# Altium schematic sheet PFE-EVSE.SchDoc
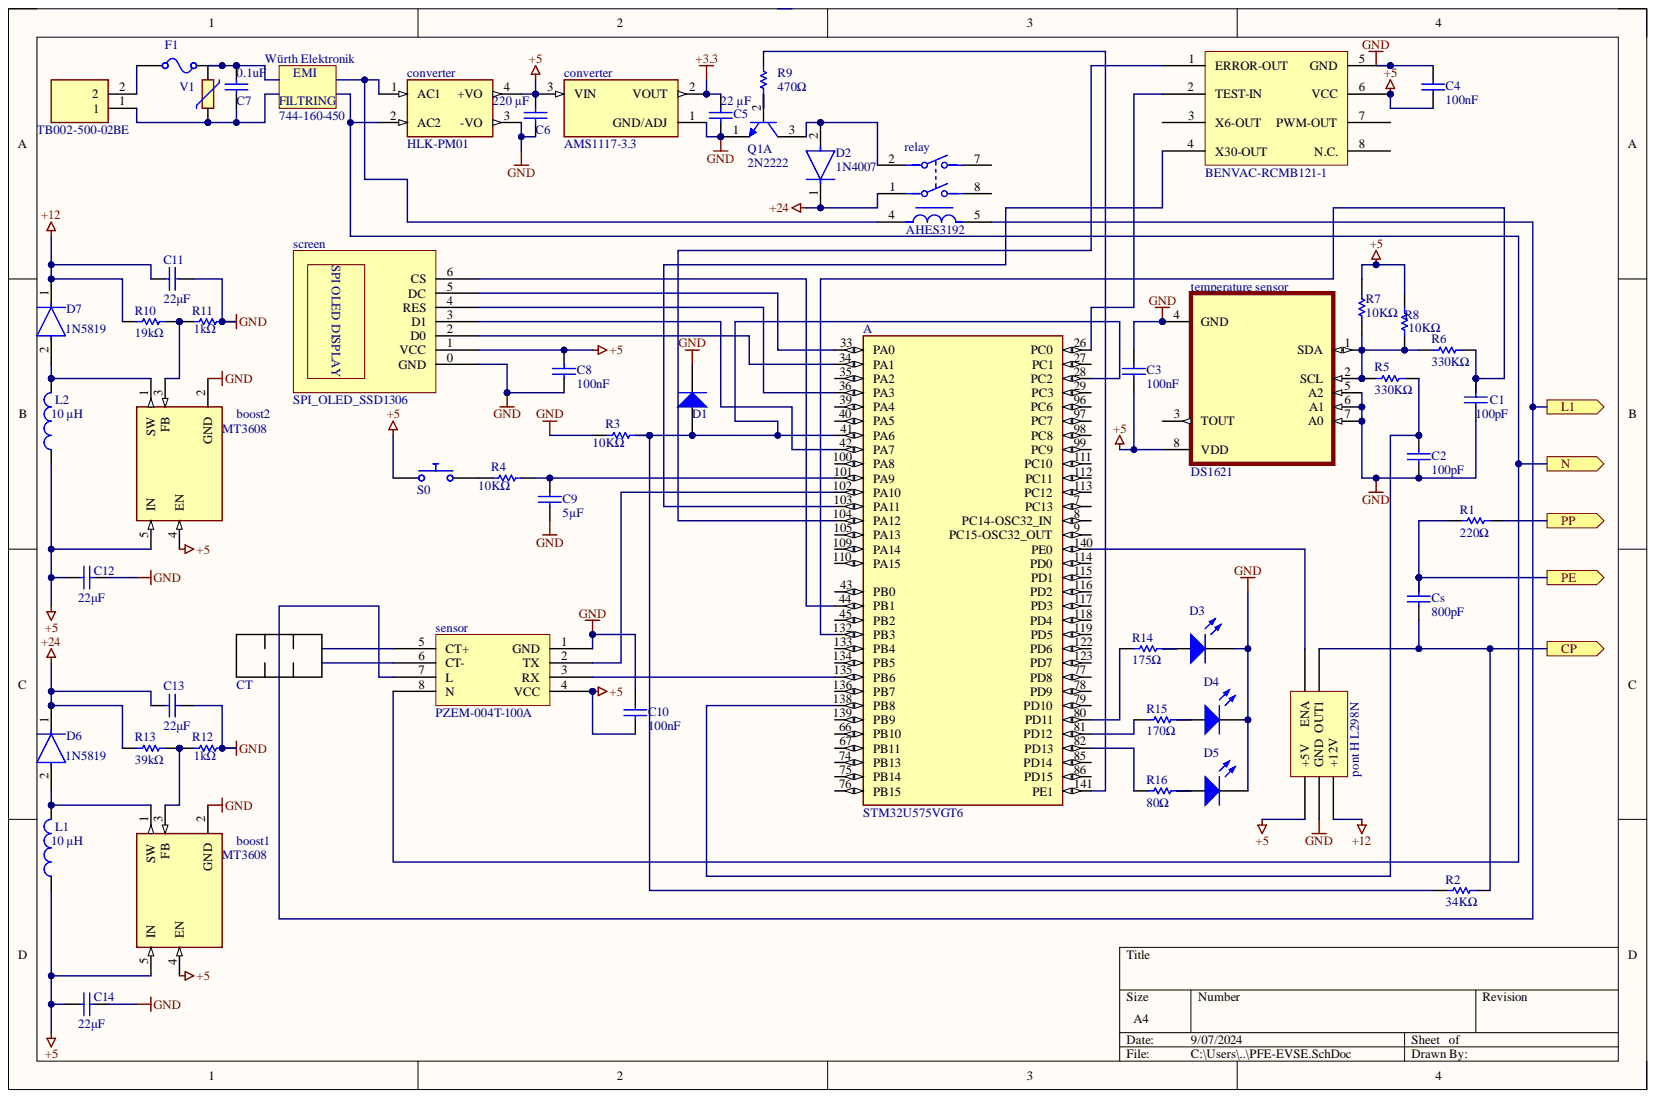
[diagram p0: PFE-EVSE.SchDoc]

## Parts
A  pont H L298N  (part )
A  Fuse  (value not drawn)
A  BENVAC-RCMB121-1
A  SPI_OLED_SSD1306
A  TB002-500-02BE  [CUI_TB002-500-02BE]
boost1  MT3608  [SOT95P280X145-6N]
boost2  MT3608  [SOT95P280X145-6N]
C1  value 100pF  (hidden comment: Capacitor)
C2  value 100pF  (hidden comment: Capacitor)
C3  value 100nF  (hidden comment: Capacitor)
C4  value 100nF  (hidden comment: Capacitor)
C5  Capacitor  (value not drawn)
C6  220 µF
C7  value 0.1uF  (hidden comment: Capacitor)
C8  value 100nF  (hidden comment: Capacitor)
C9  value 5µF  (hidden comment: Capacitor)
C10  value 100nF  (hidden comment: Capacitor)
C11  value 22μF  (hidden comment: Capacitor)
C12  value 22μF  (hidden comment: Capacitor)
C13  value 22μF  (hidden comment: Capacitor)
C14  value 22μF  (hidden comment: Capacitor)
converter  AMS1117-3.3  [SOT229P700X180-4N]
converter  HLK-PM01  [CONV_HLK-PM01]
Cs  value 800pF  (hidden comment: Capacitor)
D1  Diode  (value not drawn)
D2  1N4007  [FP-1N4007-MFG]
D3  Light-Emitting Diode  (value not drawn)
D4  Light-Emitting Diode  (value not drawn)
D5  Light-Emitting Diode  (value not drawn)
D6  (no value)  [FP-1N4007-MFG]
D7  (no value)  [FP-1N4007-MFG]
L1  value 10 μH  (hidden comment: Inductor)
L2  value 10 μH  (hidden comment: Inductor)
Q1  2N2222  [MLTC-TO-18-3]  (part A)
R1  value 220Ω  (hidden comment: Resistor)
R2  value 34KΩ  (hidden comment: Resistor)
R3  value 10KΩ  (hidden comment: Resistor)
R4  value 10KΩ  (hidden comment: Resistor)
R5  value 330KΩ  (hidden comment: Resistor)
R6  value 330KΩ  (hidden comment: Resistor)
R7  value 10KΩ  (hidden comment: Resistor)
R8  value 10KΩ  (hidden comment: Resistor)
R9  value 470Ω  (hidden comment: Resistor)
R10  value 19kΩ  (hidden comment: Resistor)
R11  value 1kΩ  (hidden comment: Resistor)
R12  value 1kΩ  (hidden comment: Resistor)
R13  value 39kΩ  (hidden comment: Resistor)
R14  value 175Ω  (hidden comment: Resistor)
R15  value 170Ω  (hidden comment: Resistor)
R16  value 80Ω  (hidden comment: Resistor)
relay  AHES3192  [FP-AHES3192-MFG]
S0  Push Button Normally Opened  (value not drawn)
sensor  PZEM-004T-100A
temperature_sensor  DS1621  [DIP794W47P254L991H457Q8]
V1  (no value)  [MOV-10D561]

## Ports
CP, L1, N, PE, PP

## Nets
+3.3: C5.2 converter.2
+5: A.1 A.6 A. boost1.4(EN) boost1.5(IN) boost2.4(EN) boost2.5(IN) C3.1 C4.1 C6.2 C8.2 C10.1 C12.2 C14.2 converter.3 converter.4(+VO) L1.1 L2.1 R7.2 R8.2 S0.1 sensor.4(VCC) temperature_sensor.8(VDD)
+12: A. C11.1 D7.1 R10.1
+24: C13.1 D2.1 D6.1 R13.1 relay.1
GND: A.0(GND) A.5 A. boost1.2(GND) boost2.2(GND) C1.1 C2.1 C3.2 C4.2 C5.1 C6.1 C8.1 C9.2 C10.2 C11.2 C12.1 C13.2 C14.1 converter.1 converter.3 D1.2 D3.2 D4.2 D5.2 Q1.1 R3.1 R11.2 R12.2 sensor.1(GND) temperature_sensor.4(GND) temperature_sensor.5(A2) temperature_sensor.6(A1) temperature_sensor.7(A0)
Net_1: A.1 A.2 A.104(PA12) C7.1 V1.1
Net_2: A.2 A.26(PC0) A.34(PA1) C7.2 V1.2
Net_3: A.3 A.42(PA7)
Net_4: A.4 A.36(PA3) A.103(PA11)
Net_5: A.5 A.33(PA0)
Net_6: A.6 A.44(PB1)
Net_7: A.7
Net_8: A.8
Net_9: A.9(PC15-OSC32_OUT)
Net_27: A.27(PC1)
Net_28: A.28(PC2) A.41(PA6) D1.1 R2.1 R3.2
Net_29: A.29(PC3)
Net_35: A.35(PA2)
Net_39: A.39(PA4)
Net_40: A.40(PA5)
Net_43: A.43(PB0)
Net_45: A.45(PB2)
Net_66: A.66(PB10)
Net_67: A.67(PB11)
Net_74: A.74(PB13)
Net_75: A.75(PB14)
Net_76: A.76(PB15)
Net_77: A.77(PD8)
Net_78: A.78(PD9)
Net_79: A.79(PD10)
Net_80: A.80(PD11) R14.1
Net_81: A.81(PD12) R15.1
Net_82: A.82(PD13) R16.1
Net_85: A.85(PD14)
Net_86: A.86(PD15)
Net_96: A.96(PC6)
Net_97: A.97(PC7)
Net_98: A.98(PC8)
Net_99: A.99(PC9)
Net_100: A.100(PA8)
Net_101: A.101(PA9) C9.1 R4.2
Net_102: A.102(PA10) sensor.2(TX)
Net_105: A.105(PA13)
Net_109: A.109(PA14)
Net_110: A.110(PA15)
Net_111: A.111(PC10)
Net_112: A.112(PC11)
Net_113: A.113(PC12)
Net_114: A.114(PD0)
Net_115: A.115(PD1)
Net_116: A.116(PD2)
Net_117: A.117(PD3)
Net_118: A.118(PD4)
Net_119: A.119(PD5)
Net_122: A.122(PD6)
Net_123: A.123(PD7)
Net_132: A.132(PB3) C1.2 R6.2
Net_133: A.133(PB4)
Net_134: A.134(PB5)
Net_135: A.135(PB6) sensor.3(RX)
Net_136: A.136(PB7)
Net_138: A.138(PB8) C2.2 R5.2
Net_139: A.139(PB9)
Net_141: A.141(PE1) R9.2
L1: relay.5 sensor.7(L) ; port L1
N: converter.2 sensor.8(N) ; port N
Net: A.140(PE0) A. Cs.1 R2.2 ; port CP
PE: Cs.2 R1.1 ; port PE
PP: R1.2 ; port PP
Netboost1_1: boost1.1(SW) D6.2 L1.2
Netboost1_3: boost1.3(FB) R12.1 R13.2
Netboost2_1: boost2.1(SW) D7.2 L2.2
Netboost2_3: boost2.3(FB) R10.2 R11.1
Netconverter_1: converter.1 relay.4
NetD2_2: D2.2 Q1.3 relay.2
NetD3_1: D3.1 R14.2
NetD4_1: D4.1 R15.2
NetD5_1: D5.1 R16.2
NetQ1_2: Q1.2 R9.1
NetR4_1: R4.1 S0.2
NetR5_1: R5.1 R6.1 R7.1 R8.1 temperature_sensor.1(SDA) temperature_sensor.2(SCL)

Not connected: relay 7 8; sensor 5(CT+) 6(CT-); temperature_sensor 3(TOUT)

## Library data of the parts
A A A sensor = Component_1: Component Kind: Standard
  A: Library Name: pont H I298N.SchLib
  A: Library Name: benvac-RCMB121-1.SchLib
  A: Library Name: SPI_OLED_SSD1306.SchLib
  sensor: Library Name: PZEM-004T.SchLib
A = Fuse: RESISTANCE: 1m; SimulationGenericComponent: True; Component Kind: Standard; Description: Fuse; Library Name: Simulation Generic Components.IntLib; Simulation: FUSE
A = TB002-500-02BE: 2~24 Poles, Screw Type, Horizontal, 5.0 Pitch, 22~12 (AWG), Terminal Block Connector; MF: CUI Devices; Availability: In Stock; Check_prices: https://www.snapeda.com/parts/TB002-500-02BE/CUI+Devices/view-part/?ref=eda; Component Kind: Standard; CUI_purchase_URL: https://www.cuidevices.com/product/interconnect/connectors/terminal-blocks/tb002-500-series?utm_source=snapeda.com&utm_medium=referral&utm_campaign=snapedaBOM; Library Name: TB002-500-02BE.IntLib; MP: TB002-500-02BE; Package: None; Price: None; Purchase-URL: https://pricing.snapeda.com/search/part/TB002-500-02BE/?ref=eda; SnapEDA_Link: https://www.snapeda.com/parts/TB002-500-02BE/CUI+Devices/view-part/?ref=snap
boost1 boost2 = MT3608: High Efficiency 1.2MHz2A Step Up Converter; MF: Aerosemi Technology Co., Ltd; Availability: Not in stock; Check_prices: https://www.snapeda.com/parts/MT3608/Aerosemi+Technology+Co.%252C+Ltd/view-part/?ref=eda; Component Kind: Standard; Library Name: MT3608.IntLib; MP: MT3608; Package: Package; Price: None; SnapEDA_Link: https://www.snapeda.com/parts/MT3608/Aerosemi+Technology+Co.%252C+Ltd/view-part/?ref=snap
C1-C14 Cs = Capacitor: SimulationGenericComponent: True; Component Kind: Standard; Description: Capacitor; Library Name: Simulation Generic Components.IntLib; Simulation: C_Capacitor_Default
converter = AMS1117-3.3: Sot223/Pkg 1-Amp 3.3-Volt Low Drop Out Voltage Regulatator; MF: Advanced Monolithic Systems; Availability: Not in stock; Check_prices: https://www.snapeda.com/parts/AMS1117-3.3/Advanced+Monolithic+Systems/view-part/?ref=eda; Component Kind: Standard; Library Name: AMS1117-3.3.IntLib; MP: AMS1117-3.3; Package: SOT-223 Seeed Technology; Price: None; SnapEDA_Link: https://www.snapeda.com/parts/AMS1117-3.3/Advanced+Monolithic+Systems/view-part/?ref=snap
converter = HLK-PM01: The HLK-PM01 is a small size low cost AC to DC converter which can take in 110V/220V AC at 50/60 Hz and give 5V 3W output. The module is can be used in designs which run on 5V and needs to be powered form the AC mains.; MF: Hi-link; Check_prices: https://www.snapeda.com/parts/hlk-pm01/Hi-link/view-part/?ref=eda; Component Kind: Standard; Library Name: HLK-PM01.IntLib; MP: hlk-pm01; Package: None; SnapEDA_Link: https://www.snapeda.com/parts/hlk-pm01/Hi-link/view-part/?ref=snap
D1 = Diode: AF: 1; BV: 0; BV_MAX: 1e99; EG: 1.11; FC: 0.5; IBV: 0.001; IS: 1e-14; MJSW: 0.33; NTUN: 30; SimulationGenericComponent: True; TNOM: 27; XTI: 3; CJO: 0; CJP: 0; Component Kind: Standard; CTA: 0; CTP: 0; Description: Diode; FCS: 0.5; FV_MAX: 1e99; IKF: 0; IKR: 0; ISR: 1e-14; JSW: 0; JTUN: 0; JTUNSW: 0; KEG: 1; KF: 0; LEVEL: 1; Library Name: Simulation Generic Components.IntLib; N: 1; NBV: 1; NR: 1; NS: 1; PHP: 1; RS: 0; Simulation: diode; TCV: 0; TLEV: 0; TLEVC: 0; TM1: 0; TM2: 0; TPB: 0; TPHP: 0; TRS: 0; TRS2: 0; TT: 0; TTT1: 0; TTT2: 0; VJ: 1; XTITUN: 3
D2 = CMP-123542-000001-1: Rectifier diode 1000 V 1 A DO-41, ,; Manufacturer: Diotec; Capacitance: 15pF; Forward Current: 1A; Max Junction Temp: +175°C; Max Repetitive Reverse Voltage: 1000V; Max Surge Forward Current: 27A; Peak Reverse Current: 5uA; Value: 15pF; Automotive: No; Category: Diode; Component Kind: Standard; ComponentLink2Description: Datasheet; ComponentLink2URL: https://datasheet.octopart.com/1N4007-Diotec-datasheet-62346875.pdf; Device Class L1: Discrete Semiconductors; Device Class L2: Diodes; Device Class L3: Rectifier Diodes; Height: 2.8mm; Ipc Land Pattern Name: DIOAD888W100L520D280; Lead Free: Yes; Library Name: Components - 02.09.24 - 11.46.SchLib; Manufacturer Part Number: 1N4007; Octopart: https://octopart.com/1n4007-diotec-4040411; Package: 1N4007; Reverse Voltage: 1000V; RoHS: TRUE; Temperature: -50°C to +175°C; Temperature Range High: +175°C; Temperature Range Low: -50°C
D3-D5 = LED: AF: 1; BV: 0; BV_MAX: 1e99; EG: 1.11; FC: 0.5; IBV: 0.001; IS: 1e-14; MJSW: 0.33; NTUN: 30; SimulationGenericComponent: True; TNOM: 27; XTI: 3; CJO: 0; CJP: 0; Component Kind: Standard; CTA: 0; CTP: 0; Description: Light-Emitting Diode; FCS: 0.5; FV_MAX: 1e99; IKF: 0; IKR: 0; ISR: 1e-14; JSW: 0; JTUN: 0; JTUNSW: 0; KEG: 1; KF: 0; LEVEL: 1; Library Name: Simulation Generic Components.IntLib; N: 1; NBV: 1; NR: 1; NS: 1; PHP: 1; RS: 0; Simulation: diode; TCV: 0; TLEV: 0; TLEVC: 0; TM1: 0; TM2: 0; TPB: 0; TPHP: 0; TRS: 0; TRS2: 0; TT: 0; TTT1: 0; TTT2: 0; VJ: 1; XTITUN: 3
D6 D7 = CMP-123542-000001-1: Rectifier diode 1000 V 1 A DO-41, ,; Manufacturer: Diotec; Manufacturer Part Number: 1N4007; Capacitance: 15pF; Forward Current: 1A; Max Junction Temp: +175°C; Max Repetitive Reverse Voltage: 1000V; Max Surge Forward Current: 27A; Peak Reverse Current: 5uA; Value: 15pF; Automotive: No; Category: Diode; Component Kind: Standard; ComponentLink2Description: Datasheet; ComponentLink2URL: https://datasheet.octopart.com/1N4007-Diotec-datasheet-62346875.pdf; Device Class L1: Discrete Semiconductors; Device Class L2: Diodes; Device Class L3: Rectifier Diodes; Height: 2.8mm; Ipc Land Pattern Name: DIOAD888W100L520D280; Lead Free: Yes; Library Name: Components - 02.09.24 - 11.46.SchLib; Octopart: https://octopart.com/1n4007-diotec-4040411; Package: 1N4007; Reverse Voltage: 1000V; RoHS: TRUE; Temperature: -50°C to +175°C; Temperature Range High: +175°C; Temperature Range Low: -50°C
L1 L2 = Inductor: SimulationGenericComponent: True; Component Kind: Standard; Description: Inductor; Library Name: Simulation Generic Components.IntLib; Simulation: L_Inductor_Default
Q1 = CMP-2000-05237-1: Low Power Bipolar NPN Transistor, 30 V, -65 to 200 degC, 3-Pin TO-18, RoHS, Bulk; Manufacturer: Multicomp; Collector Emitter Voltage V(br)ceo: 30V; Collector Emitter Voltage Vces: 400mV; Continuous Collector Current Ic Max: 800mA; Current Ic @ Vce Sat: 150mA; DC Current Gain hFE: 100; Full Power Rating Temperature: 25degC; Gain Bandwidth ft Min: 250MHz; No. of Pins: 3; No. of Transistors: 1; Pin Configuration: a; Power Dissipation Pd: 500mW; SVHC: NoSVHC(19-Dec-2012); Voltage Vcbo: 60V; Component Kind: Standard; Current Ic Continuous a Max: 800mA; Current Ic hFE: 150mA; Datasheet URL: http://www.farnell.com/datasheets/1662272.pdf; Datasheet Version: Rev. 1.1, 05/2012; DC Collector Current: 800mA; Gain Bandwidth ft Typ: 250MHz; Hfe Min: 100; Library Name: Components - 02.09.24 - 11.35.SchLib; Manufacturer URL: http://uk.farnell.com/b/multicomp; Package / Case: TO-18; Package Description: 3-Pin Transistor Outline Package (TO-18), Dia 5.54 mm, Pitch Pitch 1.485mm; Package Reference: TO-18-3; Power Dissipation Ptot Max: 500mW; Transistor Case Style: TO-18; Transistor Polarity: NPN
R1-R16 = Resistor: SimulationGenericComponent: True; TC1: 0; Component Kind: Standard; Description: Resistor; Library Name: Simulation Generic Components.IntLib; Simulation: R_Resistor_Default; TC2: 0
relay = CMP-00287-61559455-1: AHES3192 Electromechanical Relay DPDT-NO 35A/1A 24V 306.4Ohm Through Hole, HE-S series; Coil Current: 78mA; Coil Power: 1.88W; Coil Resistance: 306.4Ω; Coil Type: Non-Latching; Contact Current Rating: 35A; Number of Contacts: 2; Operate Time: 30ms; Relay Type: General Purpose; Release Time: 10ms; Switching Current: 40A; Throw Configuration: DPST; Ambient Temperature Range High: 70°C; Coil Voltage (DC): 24V; Component Kind: Standard; Contact Material: Silver, Tin; Current Rating: 35A; Height: 40.3mm; Library Name: Components - 02.09.24 - 11.15.SchLib; Max Operating Temperature: 55°C; Min Operating Temperature: -40°C; Mount: Through Hole; NexarPartId: 61559455; Packaging: Bulk; RoHS: Compliant; Termination: PC Pin
S0 = Push Button NO: closed: 0; Rclosed: 1m; Ropen: 1Meg; SimulationGenericComponent: True; Component Kind: Standard; Description: Push Button Normally Opened; Library Name: Simulation Generic Components.IntLib; Simulation: PUSH_BUTTON_NO
temperature_sensor = DS1621: Temperature Sensor Digital, Local -55Â°C ~ 125Â°C 8 b 8-DIP; MF: Maxim Integrated; Availability: Unavailable; Component Kind: Standard; Library Name: DS1621.IntLib; MP: DS1621; Package: DIP-8 Maxim Integrated; Price: None; SnapEDA_Link: https://www.snapeda.com/parts/DS1621/Maxim%20Integrated/view-part/1231399/?ref=snap
V1 = MOV-10D561K: 560 V 2.5 kA Varistor 1 Circuit Through Hole Disc 10mm; MF: Bourns; Availability: In Stock; Check_prices: https://www.snapeda.com/parts/MOV-10D561K/Bourns/view-part/?ref=eda; Component Kind: Standard; Library Name: MOV-10D561K.IntLib; MP: MOV-10D561K; Package: Disc-10 Bourns; Price: None; Purchase-URL: https://pricing.snapeda.com/search/part/MOV-10D561K/?ref=eda; SnapEDA_Link: https://www.snapeda.com/parts/MOV-10D561K/Bourns/view-part/?ref=snap
